# Altium schematic sheet ESC.SchDoc(ESC)
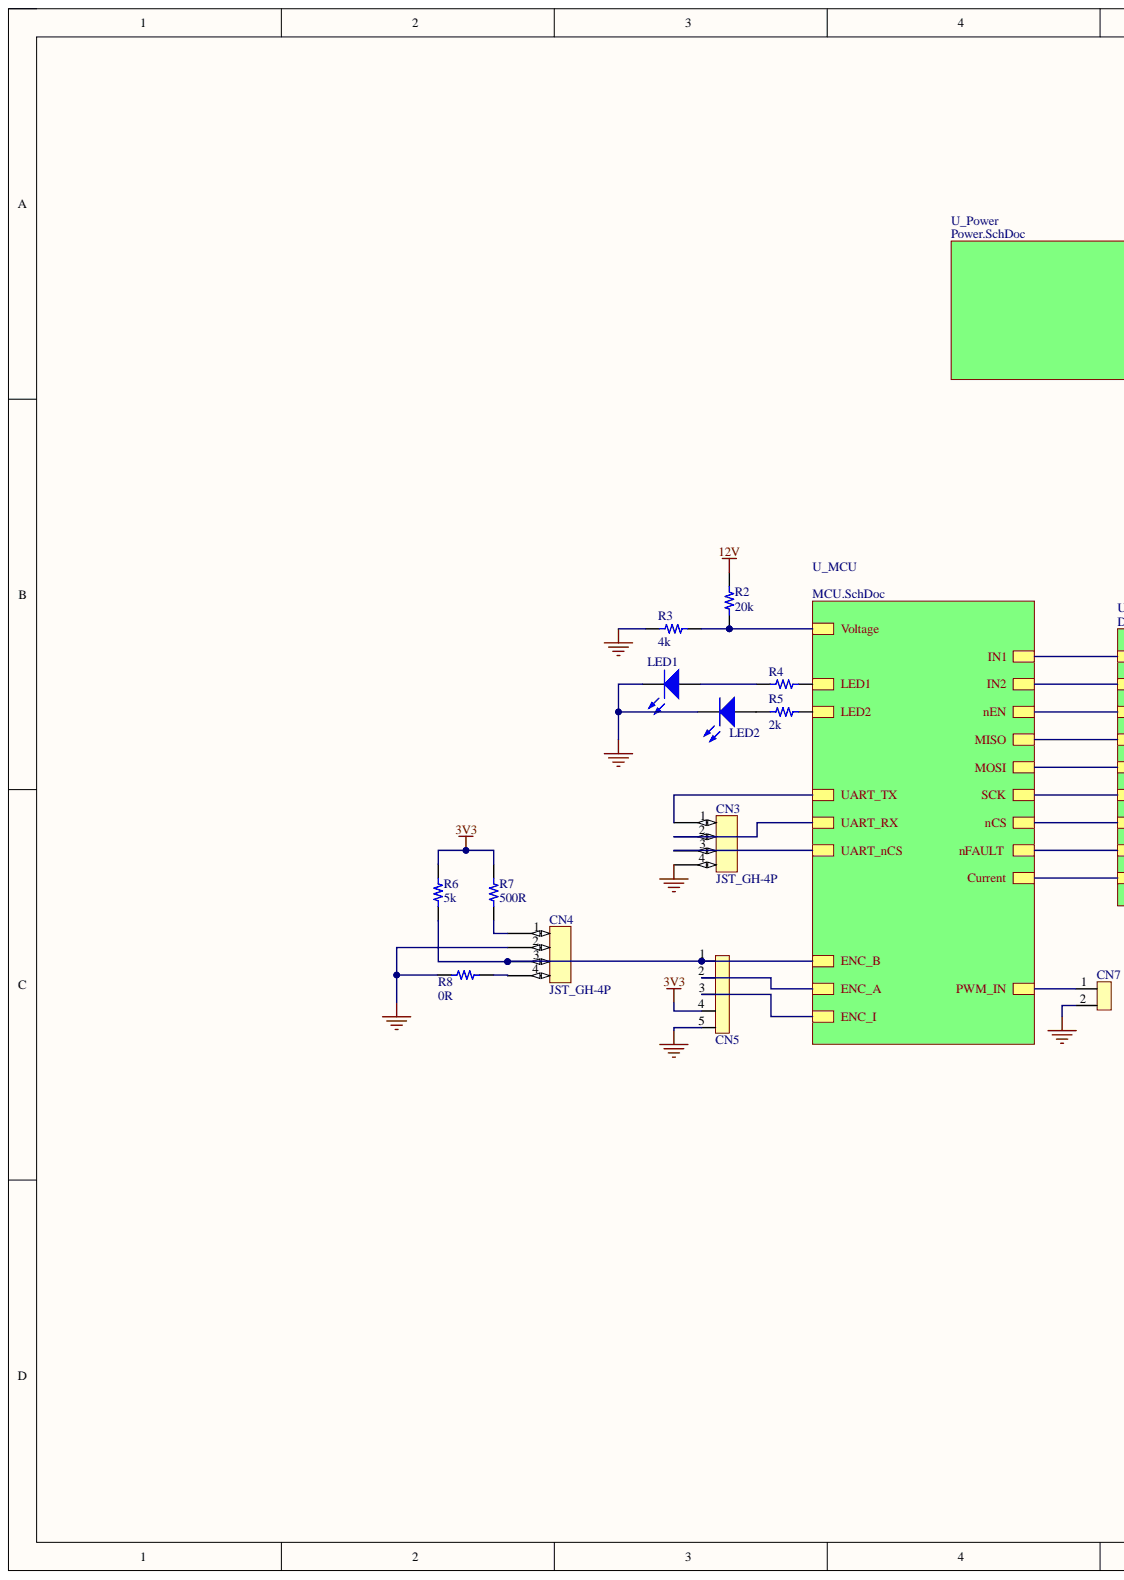
[diagram p0: ESC.SchDoc, left]
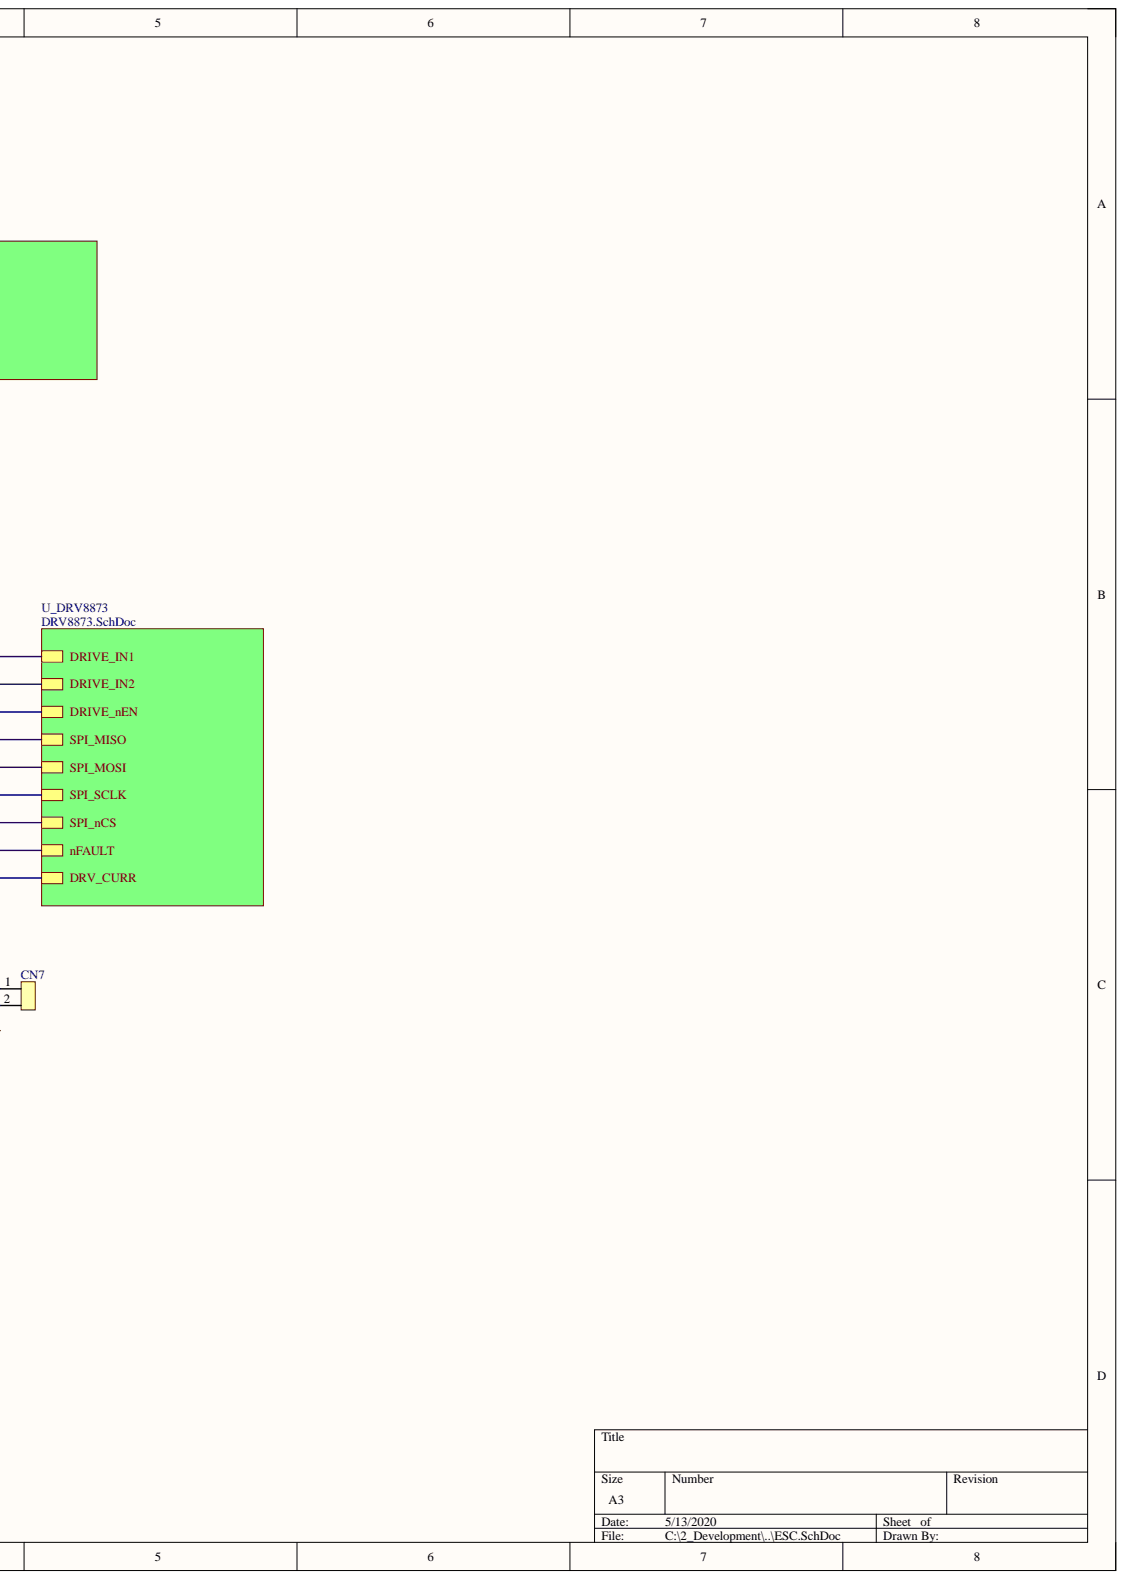
[diagram p1: ESC.SchDoc, right]

## Parts
CN3  JST_GH-4P  [JST_GH-4P]
CN4  JST_GH-4P  [JST_GH-4P]
CN5  5P-2.5mm  [5-Pin-Header]  (value not drawn)
CN7  2P-2.5mm  [2.5mm-2P]  (value not drawn)
LED1  LED  [0805-LED]
LED2  LED  [0805-LED]
R2  20k  [0805 - duplicate]
R3  4k  [0805 - duplicate]
R4  4k  [0805 - duplicate]  (value not drawn)
R5  2k  [0805 - duplicate]
R6  5k  [0805 - duplicate]
R7  500R  [0805 - duplicate]
R8  0R  [0805 - duplicate]

## Nets
3V3: CN5.4 R6.1 R7.1
12V: R2.2
GND: CN3.4 CN4.2 CN5.5 CN7.2 LED1.2 LED2.2 R3.2 R8.1
NetCN3_1: CN3.1
NetCN3_2: CN3.2
NetCN3_3: CN3.3
NetCN4_1: CN4.1 R7.2
NetCN4_3: CN4.3 CN5.1 R6.2
NetCN4_4: CN4.4 R8.2
NetCN5_2: CN5.2
NetCN5_3: CN5.3
NetCN7_1: CN7.1
NetLED1_1: LED1.1 R4.2
NetLED2_1: LED2.1 R5.2
NetR2_1: R2.1 R3.1
NetR4_1: R4.1
NetR5_1: R5.1
Current:
DRIVE_IN1:
DRIVE_IN2:
DRIVE_nEN:
MISO:
MOSI:
nCS:
nFAULT:
SCK:

## Library data of the parts
R2-R8 = Resistor: Component Kind: Standard; Library Name: Parts.SchLib
CN3 CN4 = JST_GH-4P: Component Kind: Standard; Library Name: Parts.SchLib
CN5 = 5P-2.5mm: Component Kind: Standard; Library Name: Parts.SchLib
CN7 = 2P-2.5mm: Component Kind: Standard; Library Name: Parts.SchLib
LED1 LED2 = LED: Component Kind: Standard; Library Name: Parts.SchLib
